# Altium schematic sheet P02 POWER.SchDoc("P02 POWER")
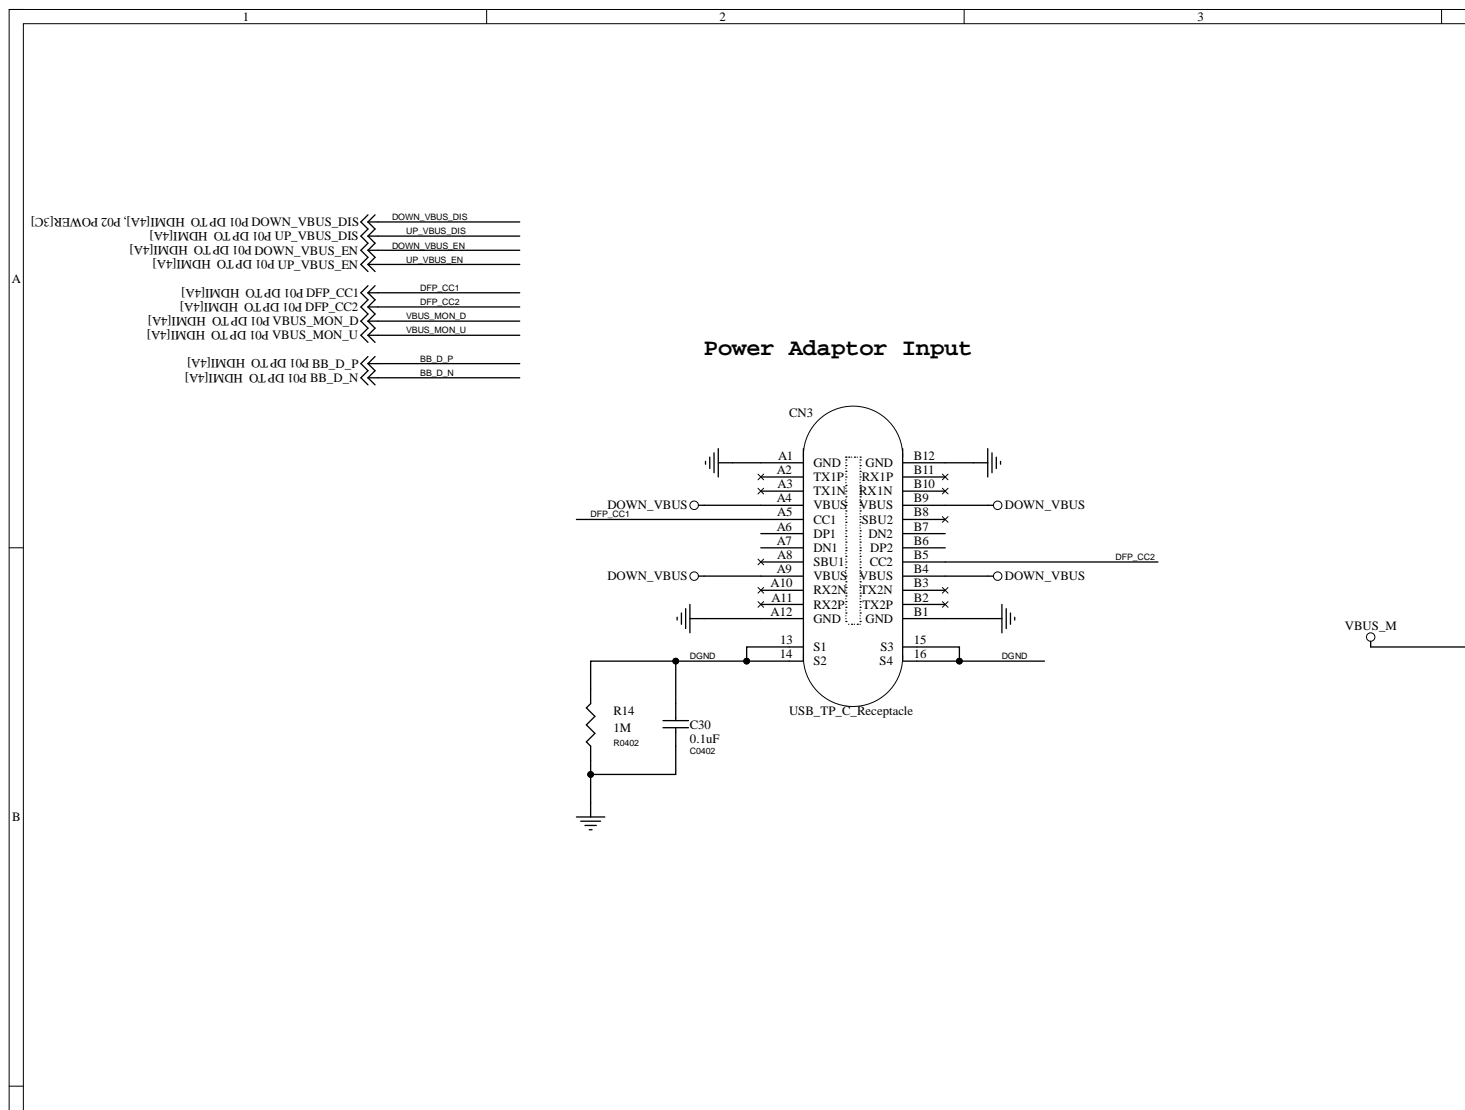
[diagram p0: P02 POWER.SchDoc, top left]
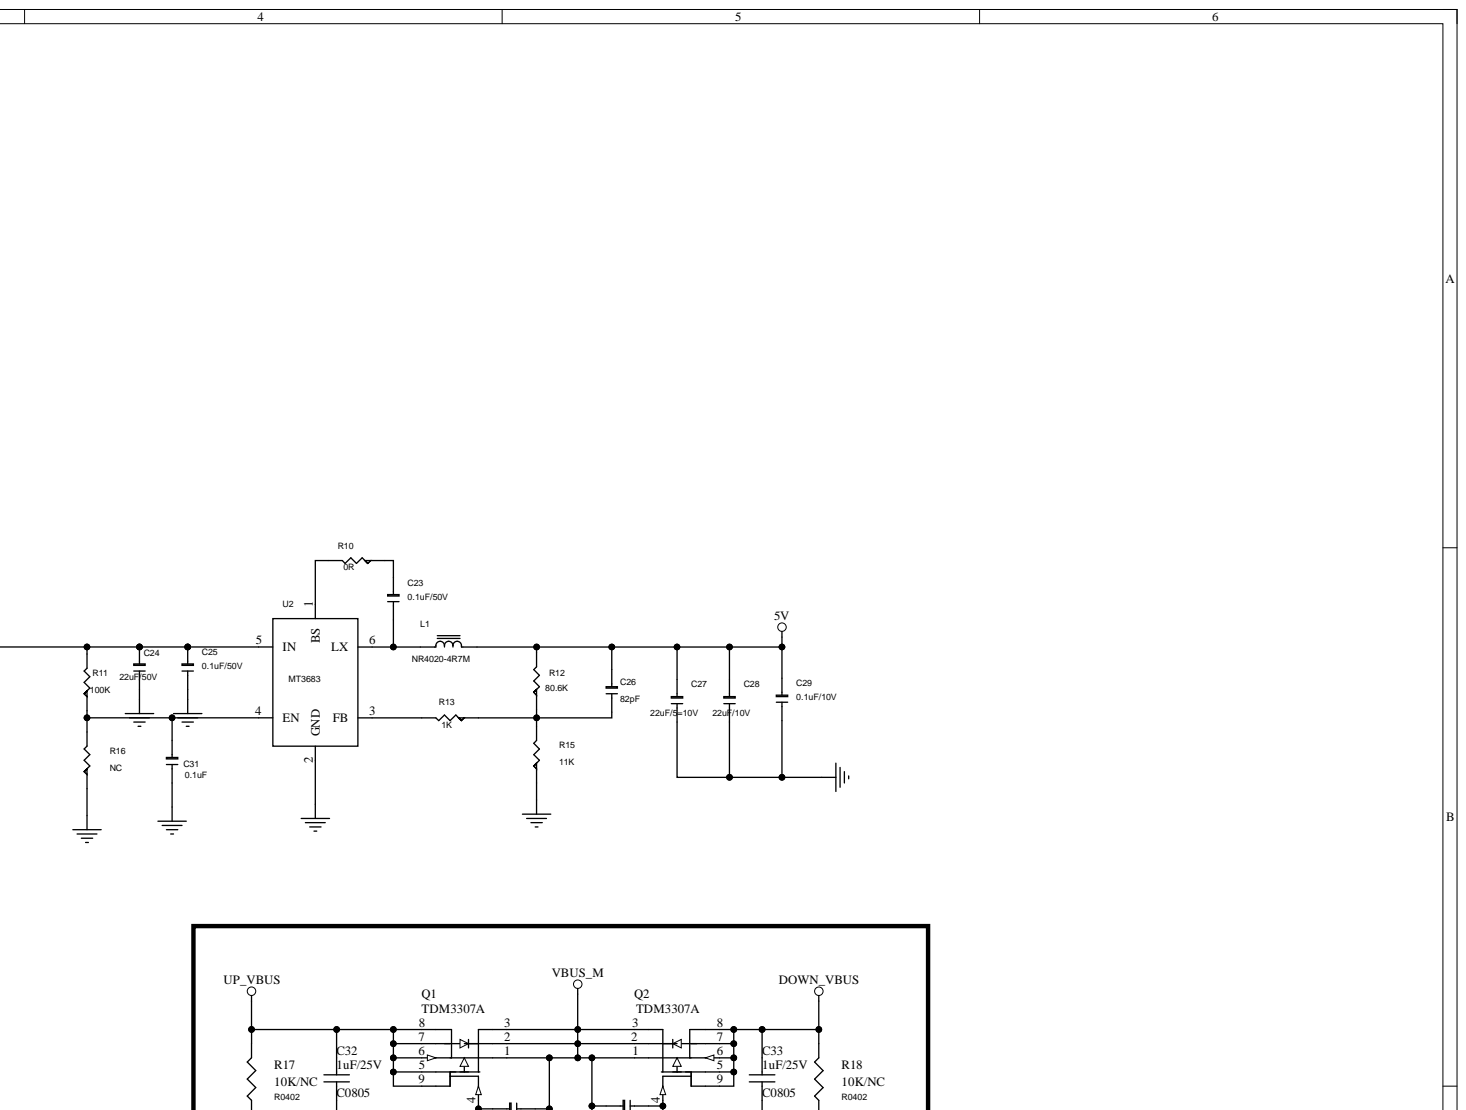
[diagram p1: P02 POWER.SchDoc, top right]
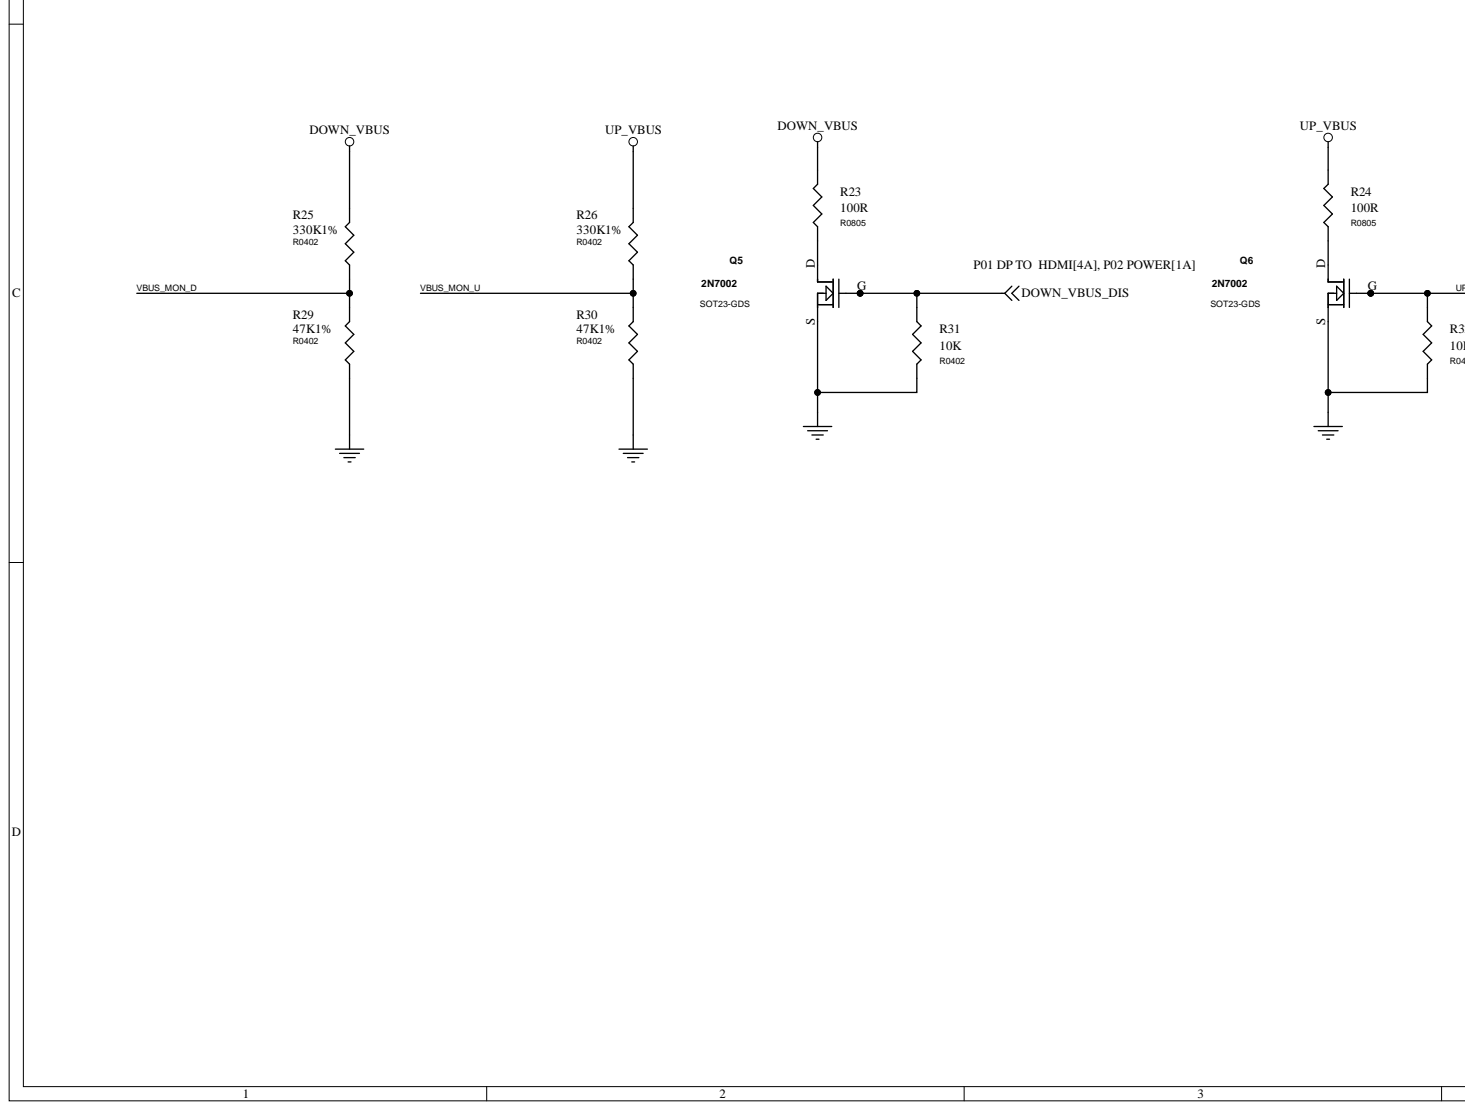
[diagram p2: P02 POWER.SchDoc, bottom left]
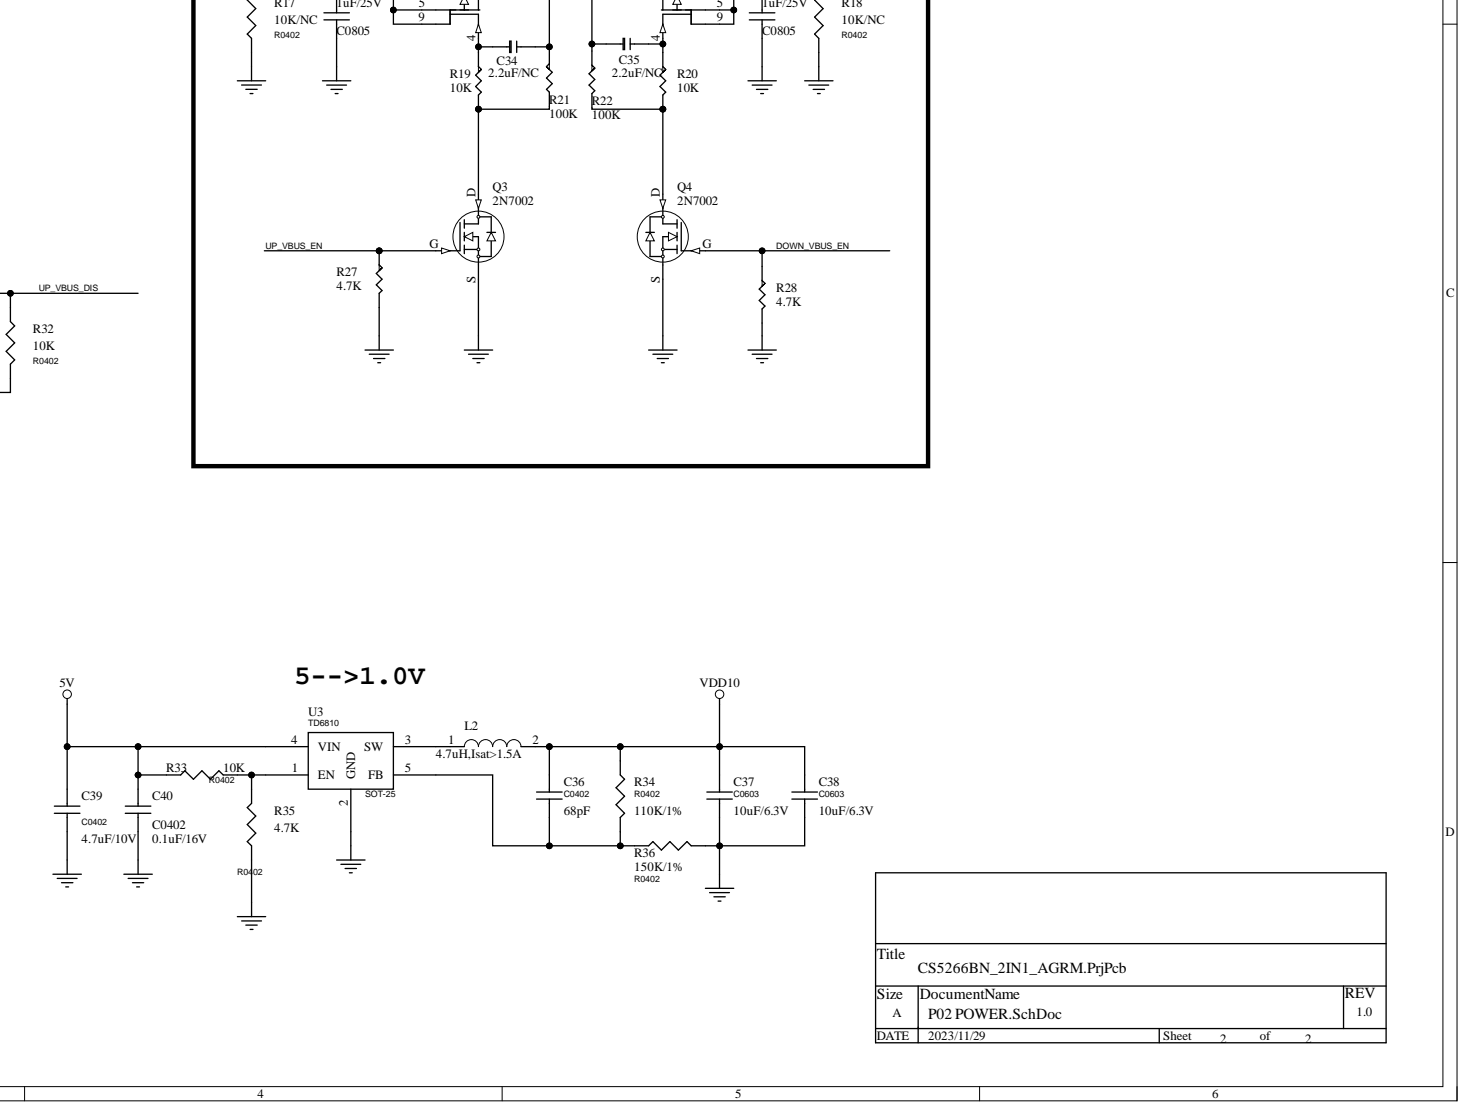
[diagram p3: P02 POWER.SchDoc, bottom right]

## Parts
C23  0.1uF/50V  [C0402]
C24  22uF/50V  [C0805]
C25  0.1uF/50V  [C0402]
C26  82pF  [C0402]
C27  22uF/5=10V  [C0603]
C28  22uF/10V  [C0603]
C29  0.1uF/10V  [C0402]
C30  0.1uF  [C0402]
C31  0.1uF  [C0402]
C32  PCB Footprint: C0805  [C0603]  (hidden comment: 1uF/25V)
C33  1uF/25V  PCB Footprint: C0805  [C0603]
C34  2.2uF/NC  [C0402]
C35  2.2uF/NC  [C0402]
C36  68pF  [C0402]
C37  10uF/6.3V  [C0603]
C38  10uF/6.3V  [C0603]
C39  4.7uF/10V  PCB Footprint: C0402  [C0603]
C40  0.1uF/16V  [C0402]
CN3  USB_TP_C_Receptacle  [XINRUIYA-TYPE-C]
L1  NR4020-4R7M  [L-NR6028-6*6]
L2  4.7uH,Isat>1.5A  [SWPA252012S]
Q1  TDM3307A  [DFN33]
Q2  TDM3307A  [DFN33]
Q3  2N7002  [SOT23-GDS]
Q4  2N7002  [SOT23-GDS]
Q5  2N7002  [SOT23-GDS]
Q6  2N7002  [SOT23-GDS]
R10  0R  [R0402]
R11  100K  [R0402]
R12  80.6K  [R0402]
R13  1K  [R0402]
R14  1M  [R0402]
R15  11K  [R0402]
R16  NC  [R0402]
R17  10K/NC  [R0402]
R18  10K/NC  [R0402]
R19  10K  [R0402]
R20  10K  [R0402]
R21  100K  [R0402]
R22  100K  [R0402]
R23  100R  [R0805]
R24  100R  [R0805]
R25  330K1%  [R0402]
R26  330K1%  [R0402]
R27  4.7K  [R0402]
R28  4.7K  [R0402]
R29  47K1%  [R0402]
R30  47K1%  [R0402]
R31  10K  [R0402]
R32  10K  [R0402]
R33  10K  [R0402]
R34  110K/1%  PCB Footprint: R0402  [R0603]
R35  4.7K  [R0402]
R36  150K/1%  PCB Footprint: R0402  [R0603]
U2  MT3683  [SOT23-6]
U3  TD6810  PCB Footprint: SOT-25  [SOT23-5]

## Nets
5V: C26.2 C27.2 C28.2 C29.2 C39.1 C40.1 L1.2 R12.2 R33.1 U3.4
DOWN_VBUS: C33.1 CN3.A4 CN3.A9 CN3.B4 CN3.B9 Q2.5 Q2.6 Q2.7 Q2.8 Q2.9 R18.2 R23.2 R25.2
GND: C24.1 C25.1 C27.1 C28.1 C29.1 C30.2 C31.1 C32.2 C33.2 C37.2 C38.2 C39.2 C40.2 CN3.A1 CN3.A12 CN3.B1 CN3.B12 Q3.S Q4.S Q5.S Q6.S R14.1 R15.1 R16.1 R17.1 R18.1 R27.2 R28.2 R29.1 R30.1 R31.2 R32.2 R35.1 R36.2 U2.2 U3.2
UP_VBUS: C32.1 Q1.5 Q1.6 Q1.7 Q1.8 Q1.9 R17.2 R24.2 R26.2
VBUS_M: C24.2 C25.2 C34.1 C35.2 Q1.1 Q1.2 Q1.3 Q2.1 Q2.2 Q2.3 R11.2 R21.1 R22.1 U2.5
VDD10: C36.1 C37.1 C38.1 L2.2 R34.1
DFP_CC1: CN3.A5
DFP_CC2: CN3.B5
DGND: C30.1 CN3.13 CN3.14 CN3.15 CN3.16 R14.2
DOWN_VBUS_DIS: Q5.G R31.1
DOWN_VBUS_EN: Q4.G R28.1
UP_VBUS_DIS: Q6.G R32.1
UP_VBUS_EN: Q3.G R27.1
VBUS_MON_D: R25.1 R29.2
VBUS_MON_U: R26.1 R30.2
NetC23_1: C23.1 L1.1 U2.6
NetC23_2: C23.2 R10.1
NetC26_1: C26.1 R12.1 R13.1 R15.2
NetC31_2: C31.2 R11.1 R16.2 U2.4
NetC34_2: C34.2 Q1.4 R19.1
NetC35_1: C35.1 Q2.4 R20.1
NetC36_2: C36.2 R34.2 R36.1 U3.5
NetL2_1: L2.1 U3.3
NetQ3_D: Q3.D R19.2 R21.2
NetQ4_D: Q4.D R20.2 R22.2
NetQ5_D: Q5.D R23.1
NetQ6_D: Q6.D R24.1
NetR10_2: R10.2 U2.1
NetR13_2: R13.2 U2.3
NetR33_2: R33.2 R35.2 U3.1
BB_D_N:
BB_D_P:

Not connected: CN3 A2 A3 A6 A7 A8 A10 A11 B2 B3 B6 B7 B8 B10 B11

## Library data of the parts
C23-C29 = C_Dup2: Part Number: 99G12-000985; approval status: A; Dsc1: CAP ML; Dsc2: 0.1uF; Dsc3: K; Dsc4: 50V; Dsc5: X5R; Dsc6: SMT0603,THICKNESS=0.80mm; Dsc7: UMK107BJ104KA; Dsc8: TAIYO; ID: 14512; Qualify: YES; QUAN: 3700; 弧: CAP ML 0.1uF K 50V X5R SMT0603; Component Kind: Standard; Cost: 0.00; Date: 12302002; Part Type: SMD CAP
C30 C36-C39 = CAP 0603_5: Component Kind: Standard
  C30 C36: PCB Footprint: C0402
  C37 C38: PCB Footprint: C0603
C31 = C_Dup2: Part Number: 99G12-000985; approval status: A; Dsc1: CAP ML; Dsc3: K; Dsc4: 50V; Dsc5: X5R; Dsc6: SMT0603,THICKNESS=0.80mm; Dsc7: UMK107BJ104KA; Dsc8: TAIYO; ID: 14512; Qualify: YES; QUAN: 3700; 弧: CAP ML 0.1uF K 50V X5R SMT0603; Component Kind: Standard; Cost: 0.00; Date: 12302002; Dsc2: 0.1uF; Part Type: SMD CAP
C32 C33 = C_7: Component Kind: Standard
C34 C35 = C: Part Number: 99H12-008857; approval status: A; Dsc1: CAP ML; Dsc2: CL21A225KB9LNNC; Dsc3: 2.2 uF; Dsc4: K; Dsc5: 50V; Dsc6: X5R; Dsc7: SMT0805,THICKNESS=0.9∮0.1mm; Dsc8: SAMSUNG; DSCX: CAP ML CL21A225KB9LNNC 2.2 uF K 50V X5R SMT0805,THICKNESS=0.9∮0.1mm SAMSUNG; ID: 35,440; Qualify: YES; QUAN: 455; 弧: CAP ML CL21A225KB9LNNC 2.2 uF; Component Kind: Standard; Cost: 0.02; Date: 12302002; Part Type: SMD CAP; PCB Footprint: C0402
C40 = CAP 0603: Component Kind: Standard; PCB Footprint: C0402
CN3 = USB_TP_C_Receptacle: PCB Footprint: TYPEC_16_A_16; Component Kind: Standard
L1 = INDUCTOR: Part Number: 99-23-02193G; Approval Sheet: \\tp_mi05\BackUp\VPSD\Data Sheet\Data Sheet\99-23-03\99-23-02193G.pdf; approval status: PL; Dsc2: SPC-04702-100; Dsc3: LQM2MPN3R3NG0; Dsc4: N; Dsc5: 1.02A; Dsc6: 4.7*4.7*2mm; Dsc8: TMP; ID: 9302; Qualify: YES; QUAN: 0; 弧: INDUCTOR SPC-04702-100 10uH N; Component Kind: Standard; Cost: 0.21; Date: 12302002; Dsc1: INDUCTOR; Part Type: SMD INDUCTOR
L2 = INDUCTOR_5: Component Kind: Standard; PCB Footprint: SWPA252012S
Q1 Q2 = MOS-P_SO8_1: Part Number: 99-10-030379; approval status: PL; Dsc1: TRANSISTOR FET P CHANNEL; Dsc2: APM4835KC; Dsc3: SOIC; Dsc4: 8PIN; Dsc8: ANPEC; DSCX: TRANSISTOR FET P CHANNEL APM4835KC SOIC 8PIN    ANPEC; ID: 6641; PCB Footprint: DFN_3X3; Qualify: YES; QUAN: 0; 弧: TRANSISTOR FET P CHANNEL APM48; Component Kind: Standard; Cost: 0.22; Date: 12302002; Part Type: SMD TRANSISTOR
Q3 = 2N7002_4: Part Number: 99G10-040024; Approval Sheet: \\tp_mi05\BackUp\VPSD\Data Sheet\Data Sheet\99G\99G10-04\99G10-040024.pdf; approval status: A; Dsc1: TRANSISTOR FET N CHANNEL; Dsc3: SOT23; Dsc4: 3PIN; Dsc8: PHILIPS(NXP); DSCX: TRANSISTOR FET N CHANNEL 2N7002 SOT23 3PIN    PHILIPS(NXP); ID: 16007; Qualify: YES; QUAN: 5150; 弧: TRANSISTOR FET N CHANNEL 2N700; Component Kind: Standard; Cost: 0.01; Date: 12302002; Dsc2: 2N7002; Part Type: SMD TRANSISTOR; PCB Footprint: SOT23-GDS
Q4 = 2N7002_3: Part Number: 99G10-040024; Approval Sheet: \\tp_mi05\BackUp\VPSD\Data Sheet\Data Sheet\99G\99G10-04\99G10-040024.pdf; approval status: A; Dsc1: TRANSISTOR FET N CHANNEL; Dsc3: SOT23; Dsc4: 3PIN; Dsc8: PHILIPS(NXP); DSCX: TRANSISTOR FET N CHANNEL 2N7002 SOT23 3PIN    PHILIPS(NXP); ID: 16007; Qualify: YES; QUAN: 5150; 弧: TRANSISTOR FET N CHANNEL 2N700; Component Kind: Standard; Cost: 0.01; Date: 12302002; Dsc2: 2N7002; Part Type: SMD TRANSISTOR; PCB Footprint: SOT23-GDS
Q5 Q6 = 2N7002_0: FET MOS 2N7002 NC SOT-23; Part Number: 84.27002.031; Geometry: SOT-23-1; Library: DISCRET1; Mapping file: 2N7002.MAP; QVL: Siliconix; Symbol_cnt: 1; Component Kind: Standard; PCB Footprint: SOT23-GDS; Symbol: 2N7002
R10-R13 R15 R16 = R_Dup3: Part Number: 99G19-000093; approval status: NA; Dsc1: RES CHIP; Dsc2: 100K OHM; Dsc3: J; Dsc4: 1/10W; Dsc5: CF; Dsc6: SMT0402,THICKNESS=0.45∮0.10mm; Dsc7: RC0603JR-07100KL; Dsc8: YAGEO; ID: 15204; Qualify: YES; QUAN: 134341; 弧: RES CHIP 100K OHM J 1/10W CF S; Component Kind: Standard; Cost: 0.00; Date: 12302002; Part Type: SMD RES
R14 R17 R18 R23 R24 R31 R32 = R: Component Kind: Standard
  R14 R17 R18 R31 R32: PCB Footprint: R0402
  R23 R24: PCB Footprint: R0805
R19 R20 = RES: Part Number: 99G20-001681; approval status: NA; Dsc1: RES CHIP; Dsc2: 10K OHM; Dsc3: F; Dsc4: 1/16W; Dsc5: CF; Dsc6: SMT0402,THICKNESS=0.45∮0.10mm; Dsc7: RC0402FR-0710KL; Dsc8: YAGEO; ID: 15204; Qualify: YES; QUAN: 134341; 弧: RES CHIP 10K OHM F 1/16W CF S; Component Kind: Standard; Cost: 0.00; Date: 12302002; Part Type: SMD RES; PCB Footprint: R0402
R21 R22 = RES: Part Number: 99G19-000093; approval status: NA; Dsc1: RES CHIP; Dsc2: 100K OHM; Dsc3: J; Dsc4: 1/10W; Dsc5: CF; Dsc6: SMT0603,THICKNESS=0.45∮0.10mm; Dsc7: RC0603JR-07100KL; Dsc8: YAGEO; DSCX: RES CHIP 100K OHM J 1/10W CF SMT0603,THICKNESS=0.45∮0.10mm RC0603JR-07100KL YAGEO; ID: 17301; Qualify: YES; QUAN: 106862; 弧: RES CHIP 100K OHM J 1/10W CF S; Component Kind: Standard; Cost: 0.00; Date: 12302002; Part Type: SMD RES; PCB Footprint: R0402
R25 R26 R29 R30 R33 R35 = R_6: Component Kind: Standard; PCB Footprint: R0402
R27 R28 = RES: Part Number: 99G19-001443; approval status: A; Dsc1: RES CHIP; Dsc2: RC0402JR-074K7L; Dsc3: 4.7K OHM; Dsc4: J; Dsc5: 1/16W; Dsc6: CF; Dsc7: SMT0402,THICKNESS=0.35∮0.05mm; Dsc8: YAGEO; DSCX: RES CHIP RC0402JR-074K7L 4.7K OHM J 1/16W CF SMT0402,THICKNESS=0.35∮0.05mm YAGEO; ID: 21,879; Qualify: YES; QUAN: 25,654; 弧: RES CHIP 4.7K OHM J 1/16W CF S; Component Kind: Standard; Cost: 0; Date: 12302002; Part Type: SMD RES; PCB Footprint: R0402
R34 R36 = R-0603_12: Component Kind: Standard
U2 = SY21041: Component Kind: Standard
U3 = MIC29302_0: Component Kind: Standard
